# Altium schematic sheet analogPath.SchDoc
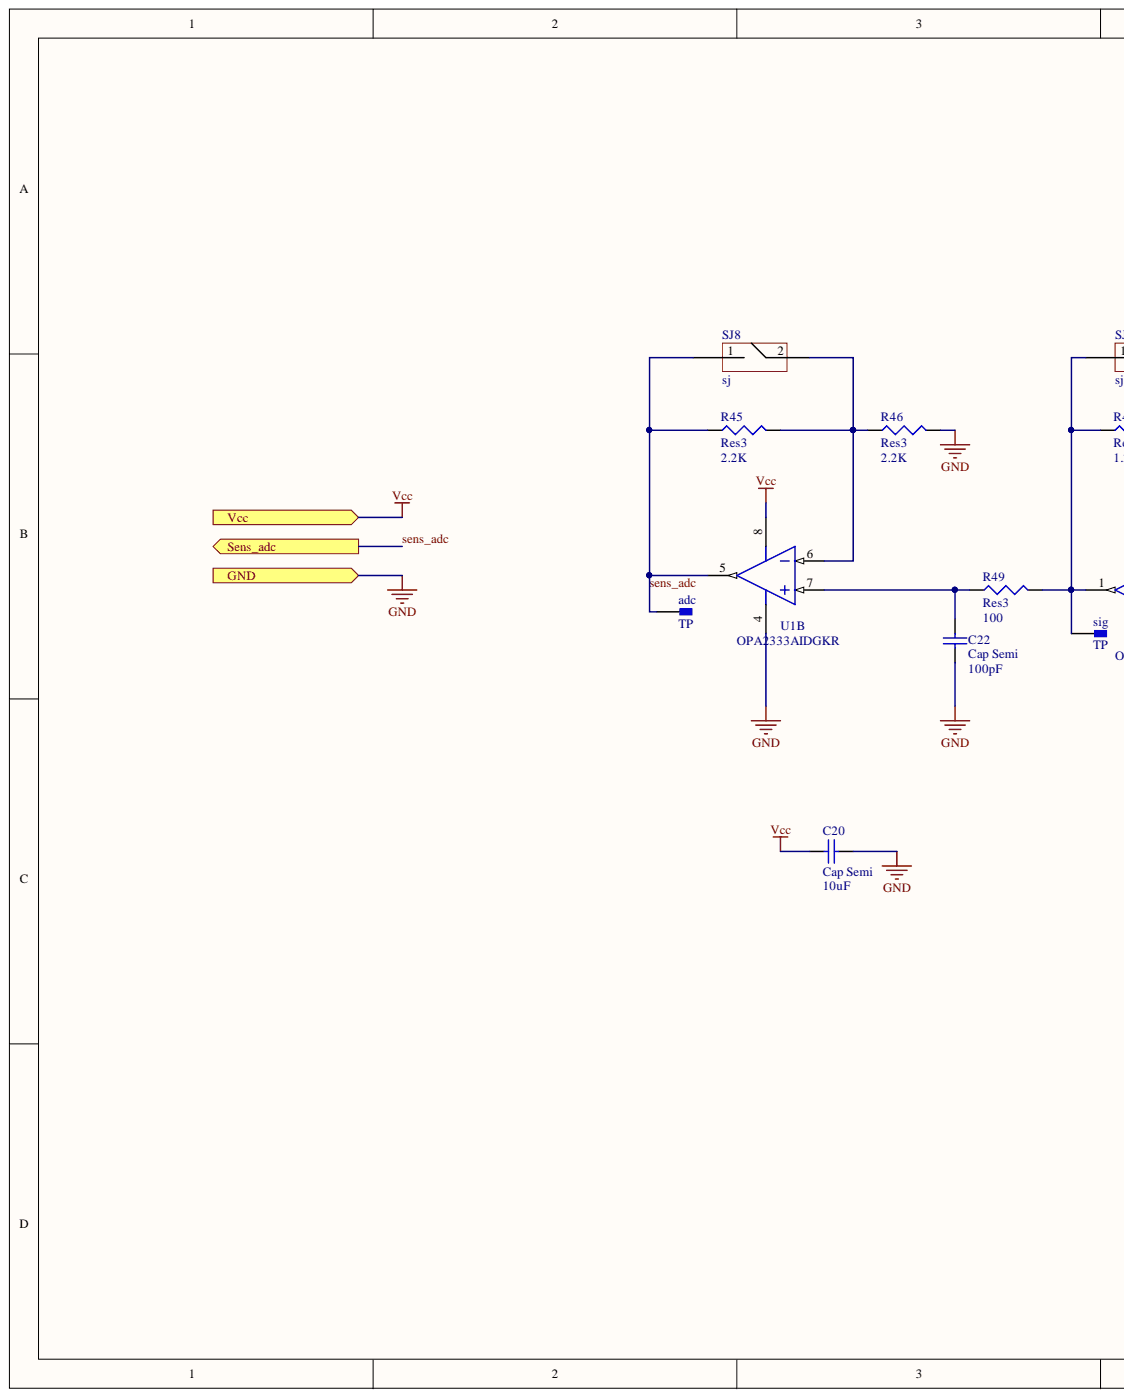
[diagram p0: analogPath.SchDoc, left]
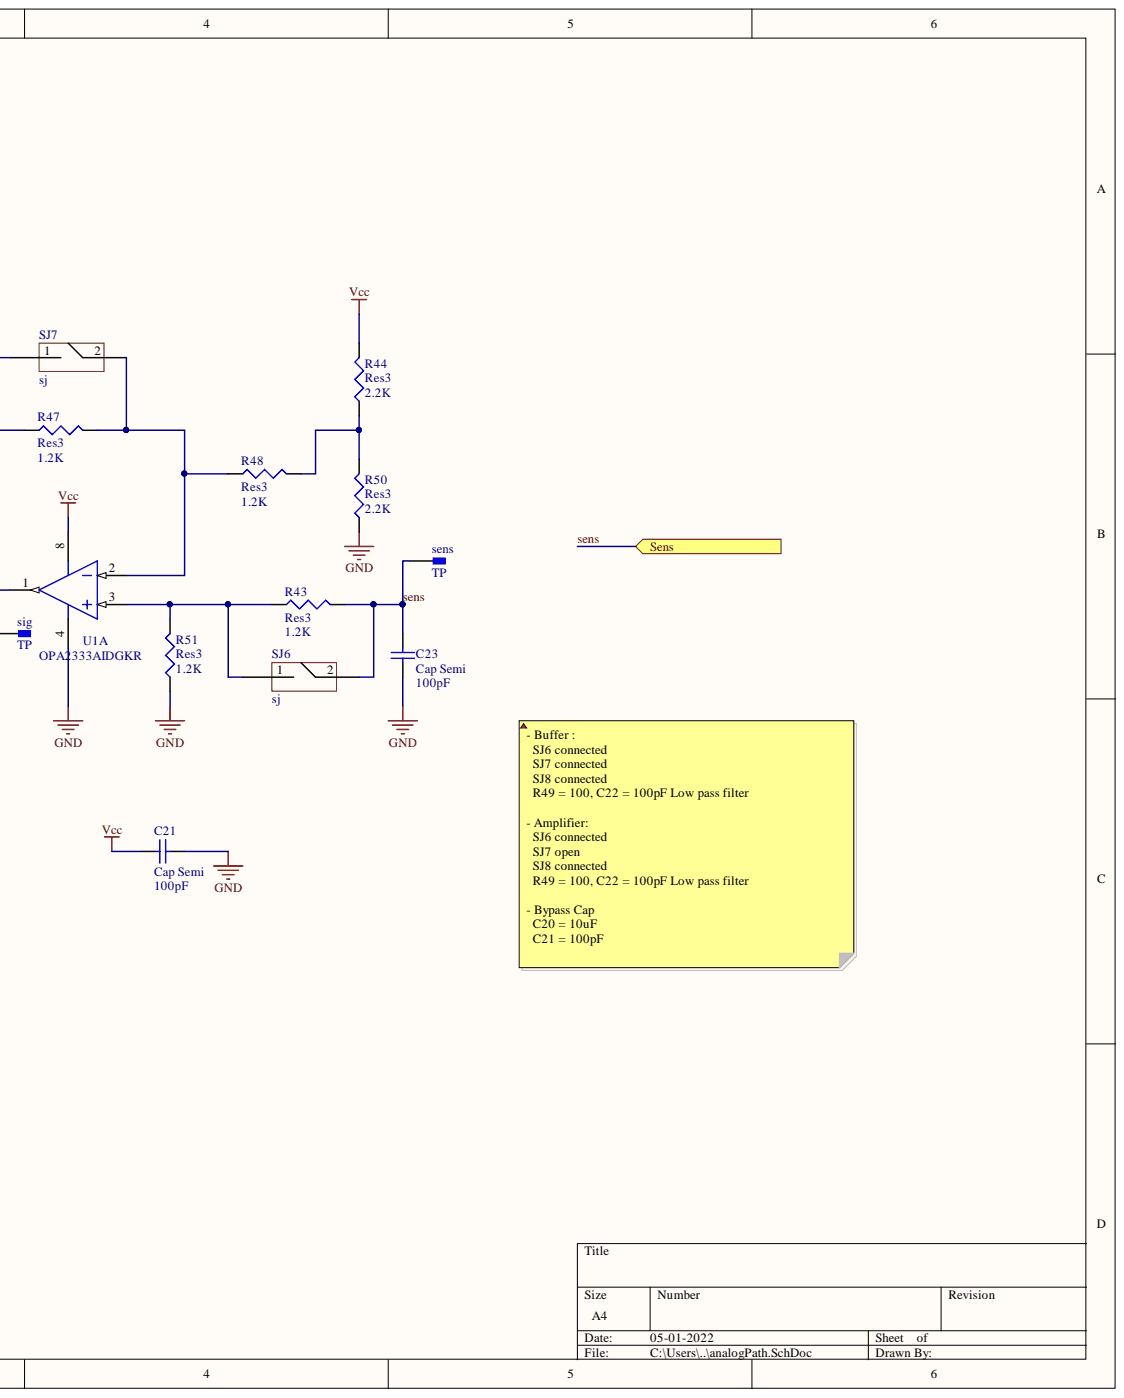
[diagram p1: analogPath.SchDoc, right]

## Parts
adc  TP  [TP]
C20  Cap Semi  value 10uF  [1608[0603]]
C21  Cap Semi  value 100pF  [1608[0603]]
C22  Cap Semi  value 100pF  [1608[0603]]
C23  Cap Semi  value 100pF  [1608[0603]]
R43  Res3  value 1.2K  [J1-0603]
R44  Res3  value 2.2K  [J1-0603]
R45  Res3  value 2.2K  [J1-0603]
R46  Res3  value 2.2K  [J1-0603]
R47  Res3  value 1.2K  [J1-0603]
R48  Res3  value 1.2K  [J1-0603]
R49  Res3  value 100  [J1-0603]
R50  Res3  value 2.2K  [J1-0603]
R51  Res3  value 1.2K  [J1-0603]
sens  TP  [TP]
sig  TP  [TP]
SJ6  sj  [SJ2]
SJ7  sj  [SJ2]
SJ8  sj  [SJ2]
U1  OPA2333AIDGKR  [MSOP-8]  (parts A,B)

## Ports
GND, Sens, Sens_adc, Vcc

## Nets
GND: C20.1 C21.2 C22.1 C23.1 R46.2 R50.1 R51.1 U1.4 ; port GND
sens: C23.2 R43.2 sens.1 SJ6.2 ; port Sens
sens_adc: adc.1 R45.1 SJ8.1 U1.5 ; port Sens_adc
Vcc: C20.2 C21.1 R44.2 U1.8 ; port Vcc
NetC22_2: C22.2 R49.1 U1.7
NetR43_1: R43.1 R51.2 SJ6.1 U1.3
NetR44_1: R44.1 R48.2 R50.2
NetR45_2: R45.2 R46.1 SJ8.2 U1.6
NetR47_1: R47.1 R49.2 sig.1 SJ7.1 U1.1
NetR47_2: R47.2 R48.1 SJ7.2 U1.2

## Library data of the parts
adc sens sig = TP: Solder test point; Component Kind: Standard; Library Name: IoWLabs.SchLib
C20 = Cap Semi: Capacitor (Semiconductor SIM Model); Manufacturer: Samsung Electro-Mechanics; Manufacturer Part Number: CL10A106MQ8NNNC; Component Kind: Standard; LatestRevisionDate: 29-May-2009; LatestRevisionNote: IPC-7351 Footprint Added.; Library Name: Miscellaneous Devices.IntLib; PackageDescription: Chip Capacitor; PackageReference: C1206; Published: 8-Jun-2000; Signal Integrity: Cap Semi; Simulation: CAP; Supplier 1: LCSC; Supplier Part Number 1: C1691; Publisher: Altium Limited
C21-C23 = Cap Semi: Capacitor (Semiconductor SIM Model); Manufacturer: YAGEO; Manufacturer Part Number: CC0603KRX7R8BB101; Component Kind: Standard; LatestRevisionDate: 29-May-2009; LatestRevisionNote: IPC-7351 Footprint Added.; Library Name: Miscellaneous Devices.IntLib; PackageDescription: Chip Capacitor; PackageReference: C0603; Published: 8-Jun-2000; Signal Integrity: Cap Semi; Simulation: CAP; Supplier 1: LCSC; Supplier Part Number 1: C519410; Publisher: Altium Limited
R43 = Res3: Resistor; Manufacturer: ROHM Semicon; Manufacturer Part Number: MCR03EZPFX1201; Value: 1.2K; Component Kind: Standard; LatestRevisionDate: 29-May-2009; LatestRevisionNote: IPC-7351 Footprint Added.; Library Name: Miscellaneous Devices.IntLib; PackageDescription: Chip Resistor; PackageReference: J1-0603; Published: 8-Jun-2000; Signal Integrity: Res; Simulation: RESISTOR; Supplier 1: LCSC; Supplier Part Number 1: C380376; Publisher: Altium Limited
R44-R46 R50 = Res3: Resistor; Manufacturer: YAGEO; Manufacturer Part Number: RC0603FR-072K2L; Component Kind: Standard; LatestRevisionDate: 29-May-2009; LatestRevisionNote: IPC-7351 Footprint Added.; Library Name: Miscellaneous Devices.IntLib; PackageDescription: Chip Resistor; PackageReference: J1-0603; Published: 8-Jun-2000; Signal Integrity: Res; Simulation: RESISTOR; Supplier 1: LCSC; Supplier Part Number 1: C114662; Publisher: Altium Limited
R47 R48 R51 = Res3: Resistor; Manufacturer: ROHM Semicon; Manufacturer Part Number: MCR03EZPFX1201; Component Kind: Standard; LatestRevisionDate: 29-May-2009; LatestRevisionNote: IPC-7351 Footprint Added.; Library Name: Miscellaneous Devices.IntLib; PackageDescription: Chip Resistor; PackageReference: J1-0603; Published: 8-Jun-2000; Signal Integrity: Res; Simulation: RESISTOR; Supplier 1: LCSC; Supplier Part Number 1: C380376; Publisher: Altium Limited
R49 = Res3: Resistor; Manufacturer: YAGEO; Manufacturer Part Number: RC0603FR-07100RL; Value: 100; Component Kind: Standard; LatestRevisionDate: 29-May-2009; LatestRevisionNote: IPC-7351 Footprint Added.; Library Name: Miscellaneous Devices.IntLib; PackageDescription: Chip Resistor; PackageReference: J1-0603; Published: 8-Jun-2000; Signal Integrity: Res; Simulation: RESISTOR; Supplier 1: LCSC; Supplier Part Number 1: C105588; Publisher: Altium Limited
SJ6-SJ8 = Jumper: solder jumper generic; Component Kind: Standard; Library Name: IoWLabs.SchLib
U1 = OPA2333: Precision OpAmps Zero-Drift 2 1.8V ~ 5.5V, ±0.9V ~ 2.75V 350kHz 0.16 V/us MSOP-8 RoHS; Manufacturer: Texas Instruments; Component Kind: Standard; Library Name: IoWLabs.SchLib; Manufacturer Part Number: OPA2333AIDGKR; Supplier 1: LCSC; Supplier Part Number 1: C19608
